# Altium schematic sheet 4-20mA输出.SchDoc(4-20mA输出)
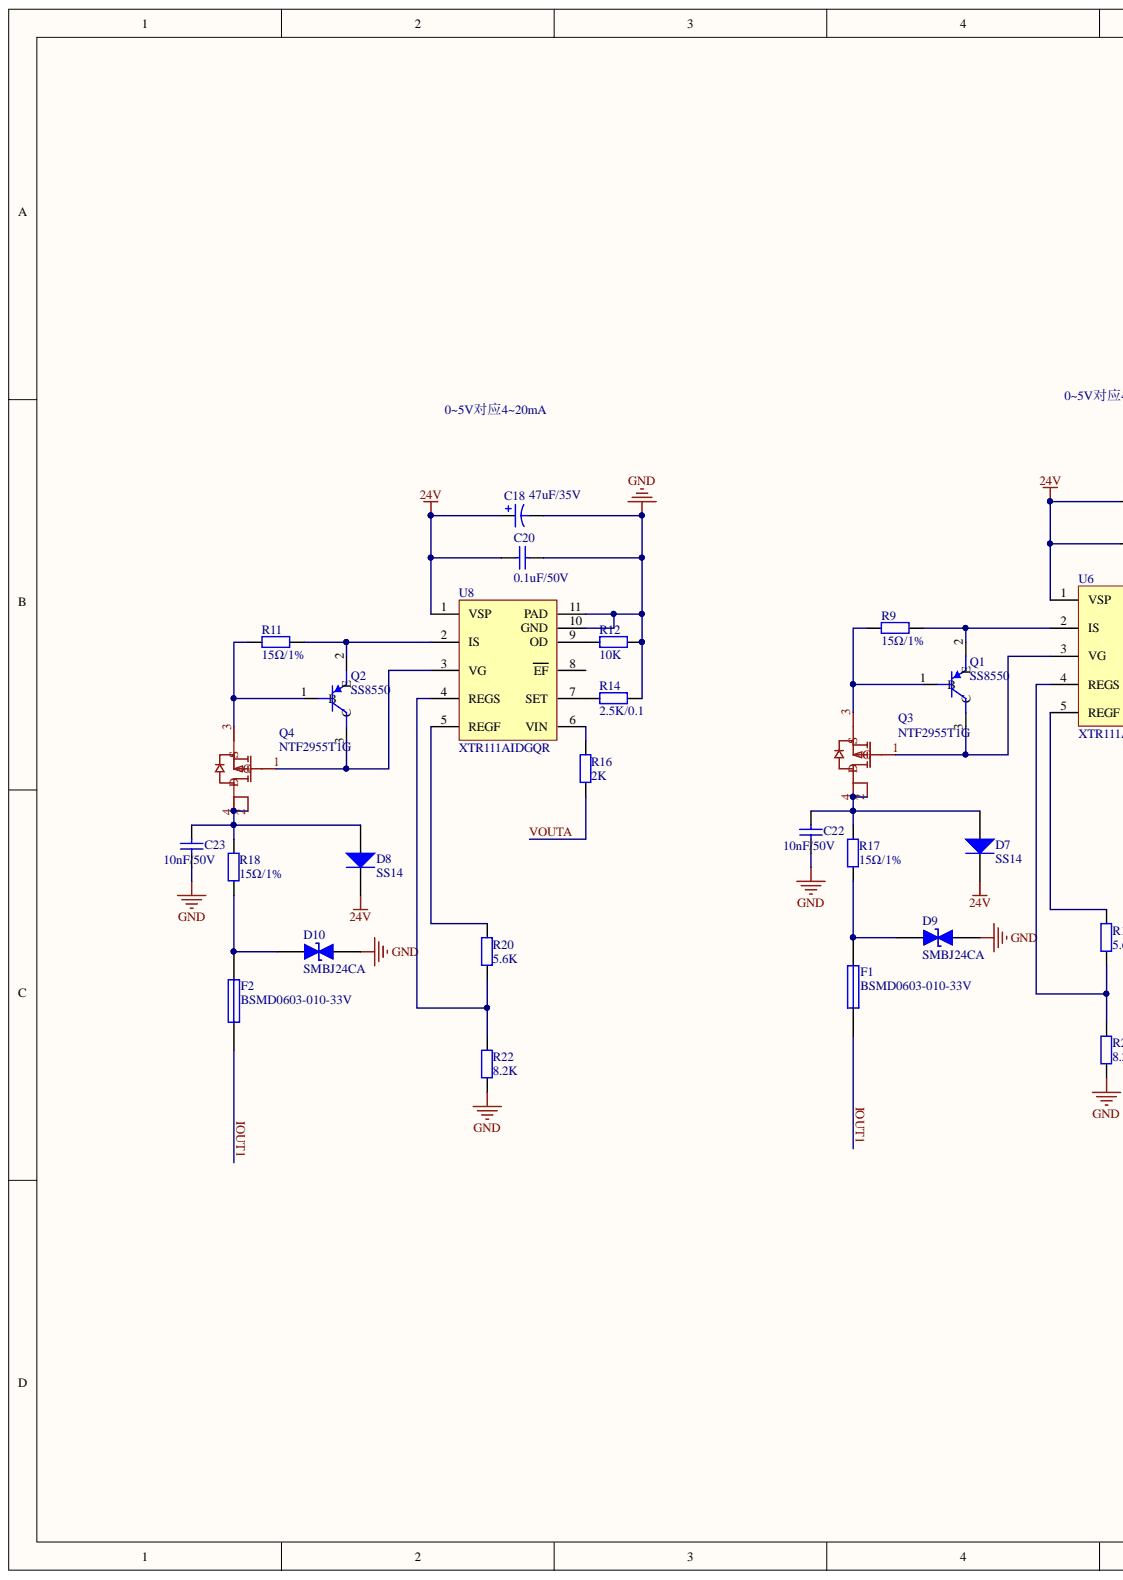
[diagram p0: 4-20mA输出.SchDoc, left]
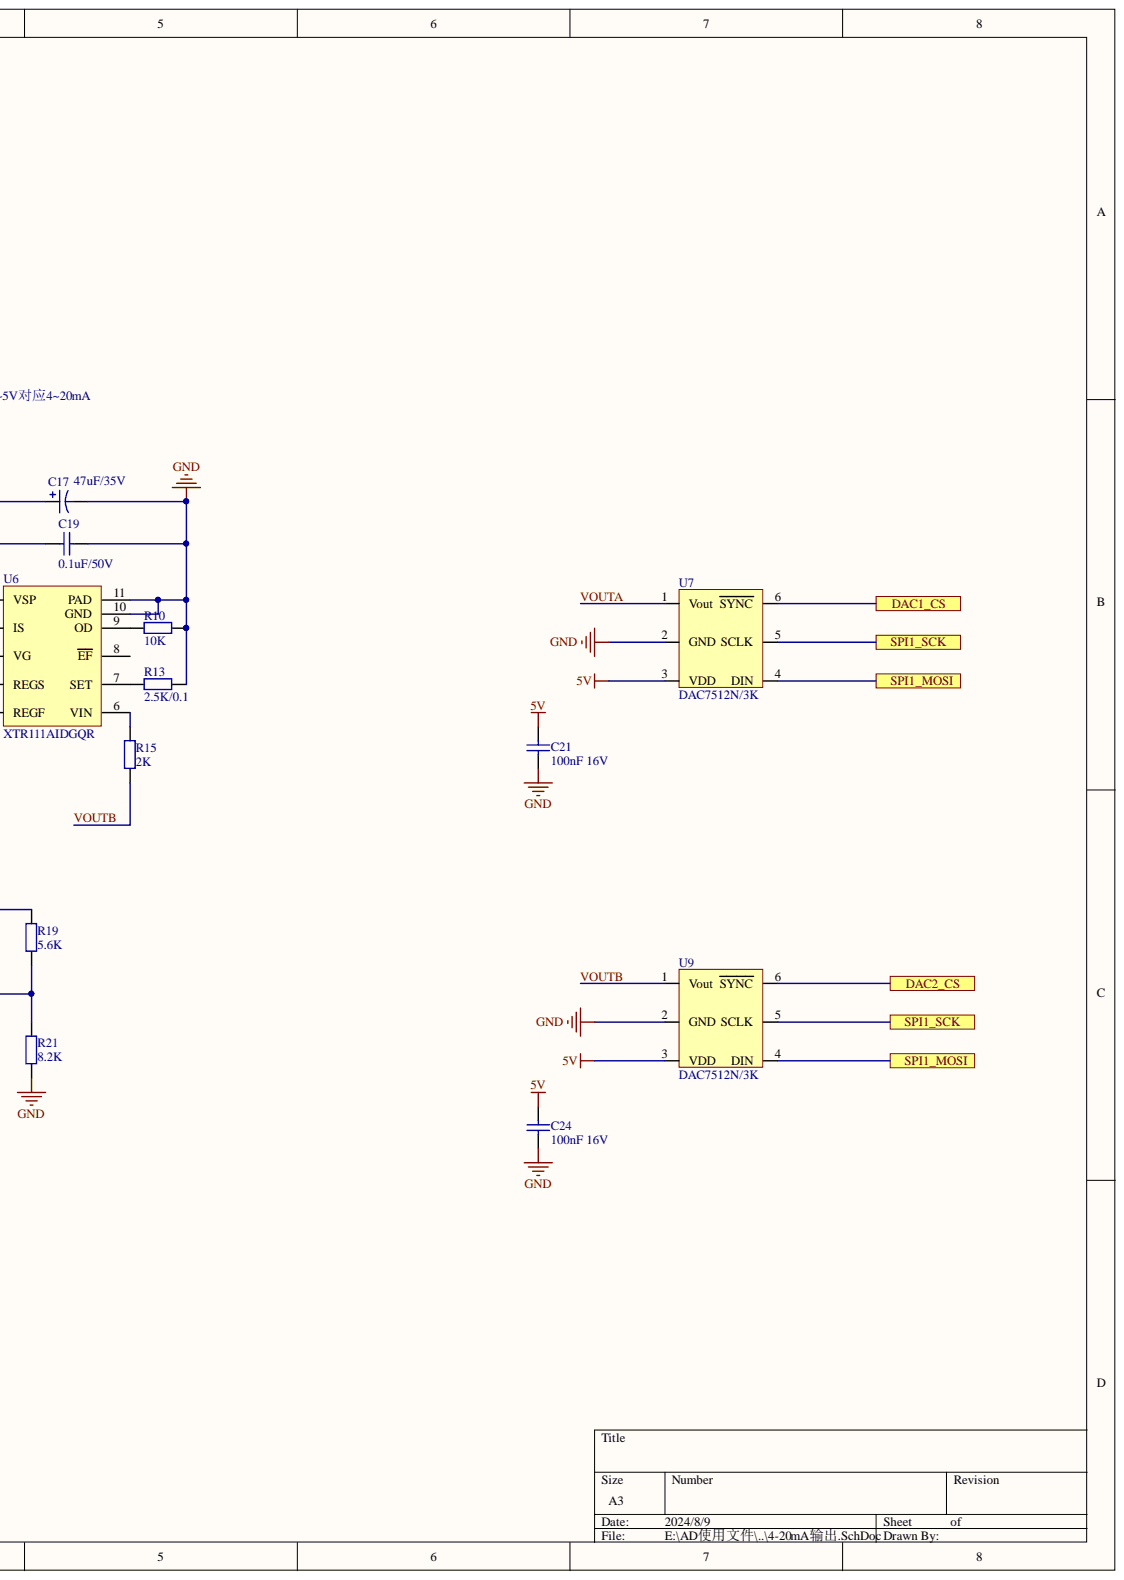
[diagram p1: 4-20mA输出.SchDoc, right]

## Parts
C17  value 47uF/35V  [C-SMD,6.3x7.7mm]  (hidden comment: VT1V470M0605)
C18  value 47uF/35V  [C-SMD,6.3x7.7mm]  (hidden comment: VT1V470M0605)
C19  0.1uF/50V  [0805-C]
C20  0.1uF/50V  [0805-C]
C21  100nF 16V  [0402_C]
C22  10nF/50V  [0805-C]
C23  10nF/50V  [0805-C]
C24  100nF 16V  [0402_C]
D7  SS14  [SMA(DO-214AC)]
D8  SS14  [SMA(DO-214AC)]
D9  SMBJ24CA  [SMA(DO-214AA)]
D10  SMBJ24CA  [SMA(DO-214AA)]
F1  BSMD0603-010-33V  [0603_R]
F2  BSMD0603-010-33V  [0603_R]
Q1  SS8550  [SOT-23]
Q2  SS8550  [SOT-23]
Q3  NTF2955T1G  [SOT-223]
Q4  NTF2955T1G  [SOT-223]
R9  15Ω/1%  [R 1210]
R10  10K  [0805-R]
R11  15Ω/1%  [R 1210]
R12  10K  [0805-R]
R13  2.5K/0.1  [0805-R]
R14  2.5K/0.1  [0805-R]
R15  2K  [0805-R]
R16  2K  [0805-R]
R17  15Ω/1%  [R 1210]
R18  15Ω/1%  [R 1210]
R19  5.6K  [0805-R]
R20  5.6K  [0805-R]
R21  8.2K  [0805-R]
R22  8.2K  [0805-R]
U6  XTR111AIDGQR  [MSOP10_M]
U7  DAC7512N/3K  [SOT-23-6]
U8  XTR111AIDGQR  [MSOP10_M]
U9  DAC7512N/3K  [SOT-23-6]

## Ports
DAC1_CS, DAC2_CS, SPI1_MOSI, SPI1_SCK

## Nets
5V: C21.2 C24.2 U7.3(VDD) U9.3(VDD)
24V: C17.1 C18.1 C19.2 C20.2 D7.2 D8.2 U6.1(VSP) U8.1(VSP)
GND: C17.2 C18.2 C19.1 C20.1 C21.1 C22.1 C23.1 C24.1 D9.1 D10.1 R10.2 R12.2 R13.2 R14.2 R21.2 R22.2 U6.10(GND) U6.11(PAD) U7.2(GND) U8.10(GND) U8.11(PAD) U9.2(GND)
DAC1_CS: U7.6(SYNC) ; port DAC1_CS
DAC2_CS: U9.6(SYNC) ; port DAC2_CS
IOUT1: F1.1 F2.1
SPI1_MOSI: U7.4(DIN) U9.4(DIN) ; port SPI1_MOSI
SPI1_SCK: U7.5(SCLK) U9.5(SCLK) ; port SPI1_SCK
VOUTA: R16.2 U7.1(Vout)
VOUTB: R15.2 U9.1(Vout)
NetC22_2: C22.2 D7.1 Q3.2 Q3.4(D) R17.1
NetC23_2: C23.2 D8.1 Q4.2 Q4.4(D) R18.1
NetD9_2: D9.2 F1.2 R17.2
NetD10_2: D10.2 F2.2 R18.2
NetQ1_1: Q1.1 Q3.3(S) R9.2
NetQ1_2: Q1.2 R9.1 U6.2(IS)
NetQ1_3: Q1.3 Q3.1(G) U6.3(VG)
NetQ2_1: Q2.1 Q4.3(S) R11.2
NetQ2_2: Q2.2 R11.1 U8.2(IS)
NetQ2_3: Q2.3 Q4.1(G) U8.3(VG)
NetR10_1: R10.1 U6.9(OD)
NetR12_1: R12.1 U8.9(OD)
NetR13_1: R13.1 U6.7(SET)
NetR14_1: R14.1 U8.7(SET)
NetR15_1: R15.1 U6.6(VIN)
NetR16_1: R16.1 U8.6(VIN)
NetR19_1: R19.1 U6.5(REGF)
NetR19_2: R19.2 R21.1 U6.4(REGS)
NetR20_1: R20.1 U8.5(REGF)
NetR20_2: R20.2 R22.1 U8.4(REGS)

Not connected: U6 8(EF); U8 8(EF)

## Library data of the parts
C17 C18 = Cap Pol1: 电解电容; Simulation: CAP; Published: 8-Jun-2000; PackageReference: RB7.6-15; PackageDescription: Polarized Capacitor (Radial); 2 Leads; Library Name: Miscellaneous Devices.IntLib; LatestRevisionNote: Re-released for DXP Platform.; LatestRevisionDate: 17-Jul-2002; Component Kind: Standard; Publisher: Altium Limited
C19 C20 C22 C23 = C(0805): 贴片电容; Component Kind: Standard; Library Name: SAM.SchLib
C21 C24 = 0402 100nF (104) 10% 16V: 贴片电容; SuppliersPartNumber: C1525; Supplier: LC; Package: 0402; Library Name: Cap.SchLib; ComponentLink1URL: http://www.szlcsc.com/product/details_1877.html; ComponentLink1Description: 供应商链接; Component Kind: Standard
D7 D8 = Diode: 肖特基二极管; Simulation: DIODE; Published: 8-Jun-2000; PackageVersion: Sep-1996; PackageReference: SMC; PackageDescription: DO-214-AB/SMC; 2 C-Bend Leads; Body 7.9 x 5.9 mm, inc. leads (LxW); Library Name: Miscellaneous Devices.IntLib; LatestRevisionNote: Re-released for DXP Platform.; LatestRevisionDate: 17-Jul-2002; Component Kind: Standard; Code_JEDEC: DO-214-AB; Publisher: Altium Limited
D9 D10 = SMF33CA: TVS二极管; Library Name: My_library.SchLib; Component Kind: Standard
F1 F2 = Fuse 1: 一次性，快速融断 125V  375ma保险丝; Simulation: FUSE; Published: 8-Jun-2000; PackageReference: PIN-W2/E2.8; PackageDescription: Thru-Hole; 2 Leads; Library Name: Miscellaneous Devices.IntLib; LatestRevisionNote: Re-released for DXP Platform.; LatestRevisionDate: 17-Jul-2002; Component Kind: Standard; Publisher: Altium Limited
Q1 Q2 = PNP: Library Name: My_library.SchLib; Component Kind: Standard
Q3 Q4 = NTF2955T1G: VDSS:60V; Id:1.7A; 1W PMOS; Library Name: My_library.SchLib; Component Kind: Standard
R9-R22 = Res2: 贴片电阻; Simulation: RESISTOR; Published: 8-Jun-2000; PackageReference: AXIAL-0.4; PackageDescription: Axial Device, Thru-Hole; 2 Leads; 0.4 in Pin Spacing; Library Name: Miscellaneous Devices.IntLib; LatestRevisionNote: Re-released for DXP Platform.; LatestRevisionDate: 17-Jul-2002; Component Kind: Standard; Publisher: Altium Limited
U7 U9 = DAC7512: Component Kind: Standard; Library Name: wgh元件库.SCHLIB
U6 U8 = XTR111AIDGQR: Library Name: My_library.SchLib; Component Kind: Standard
